# Altium schematic sheet Audio.SchDoc
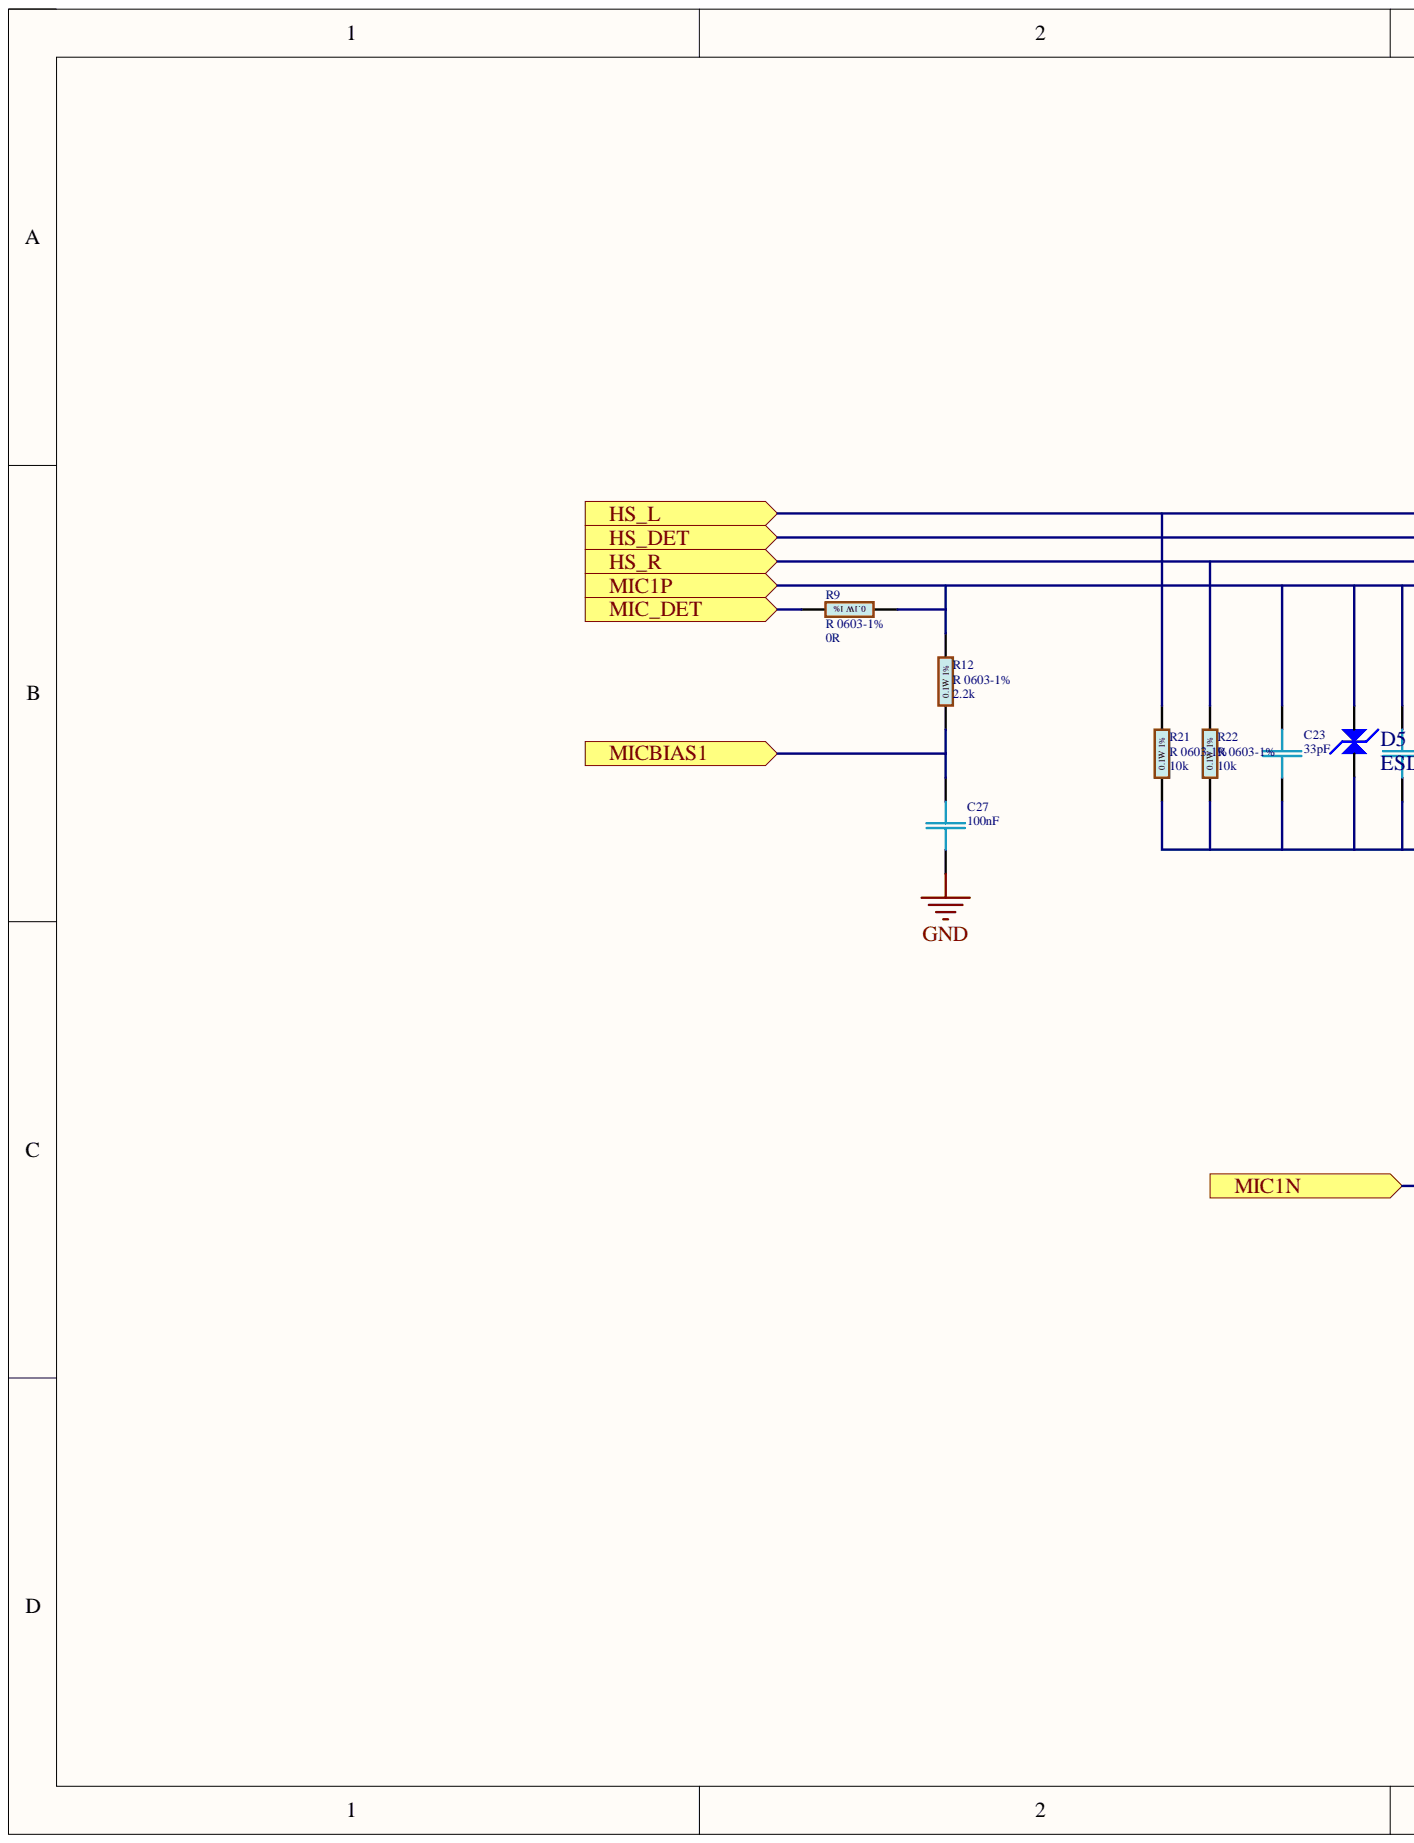
[diagram p0: Audio.SchDoc, left]
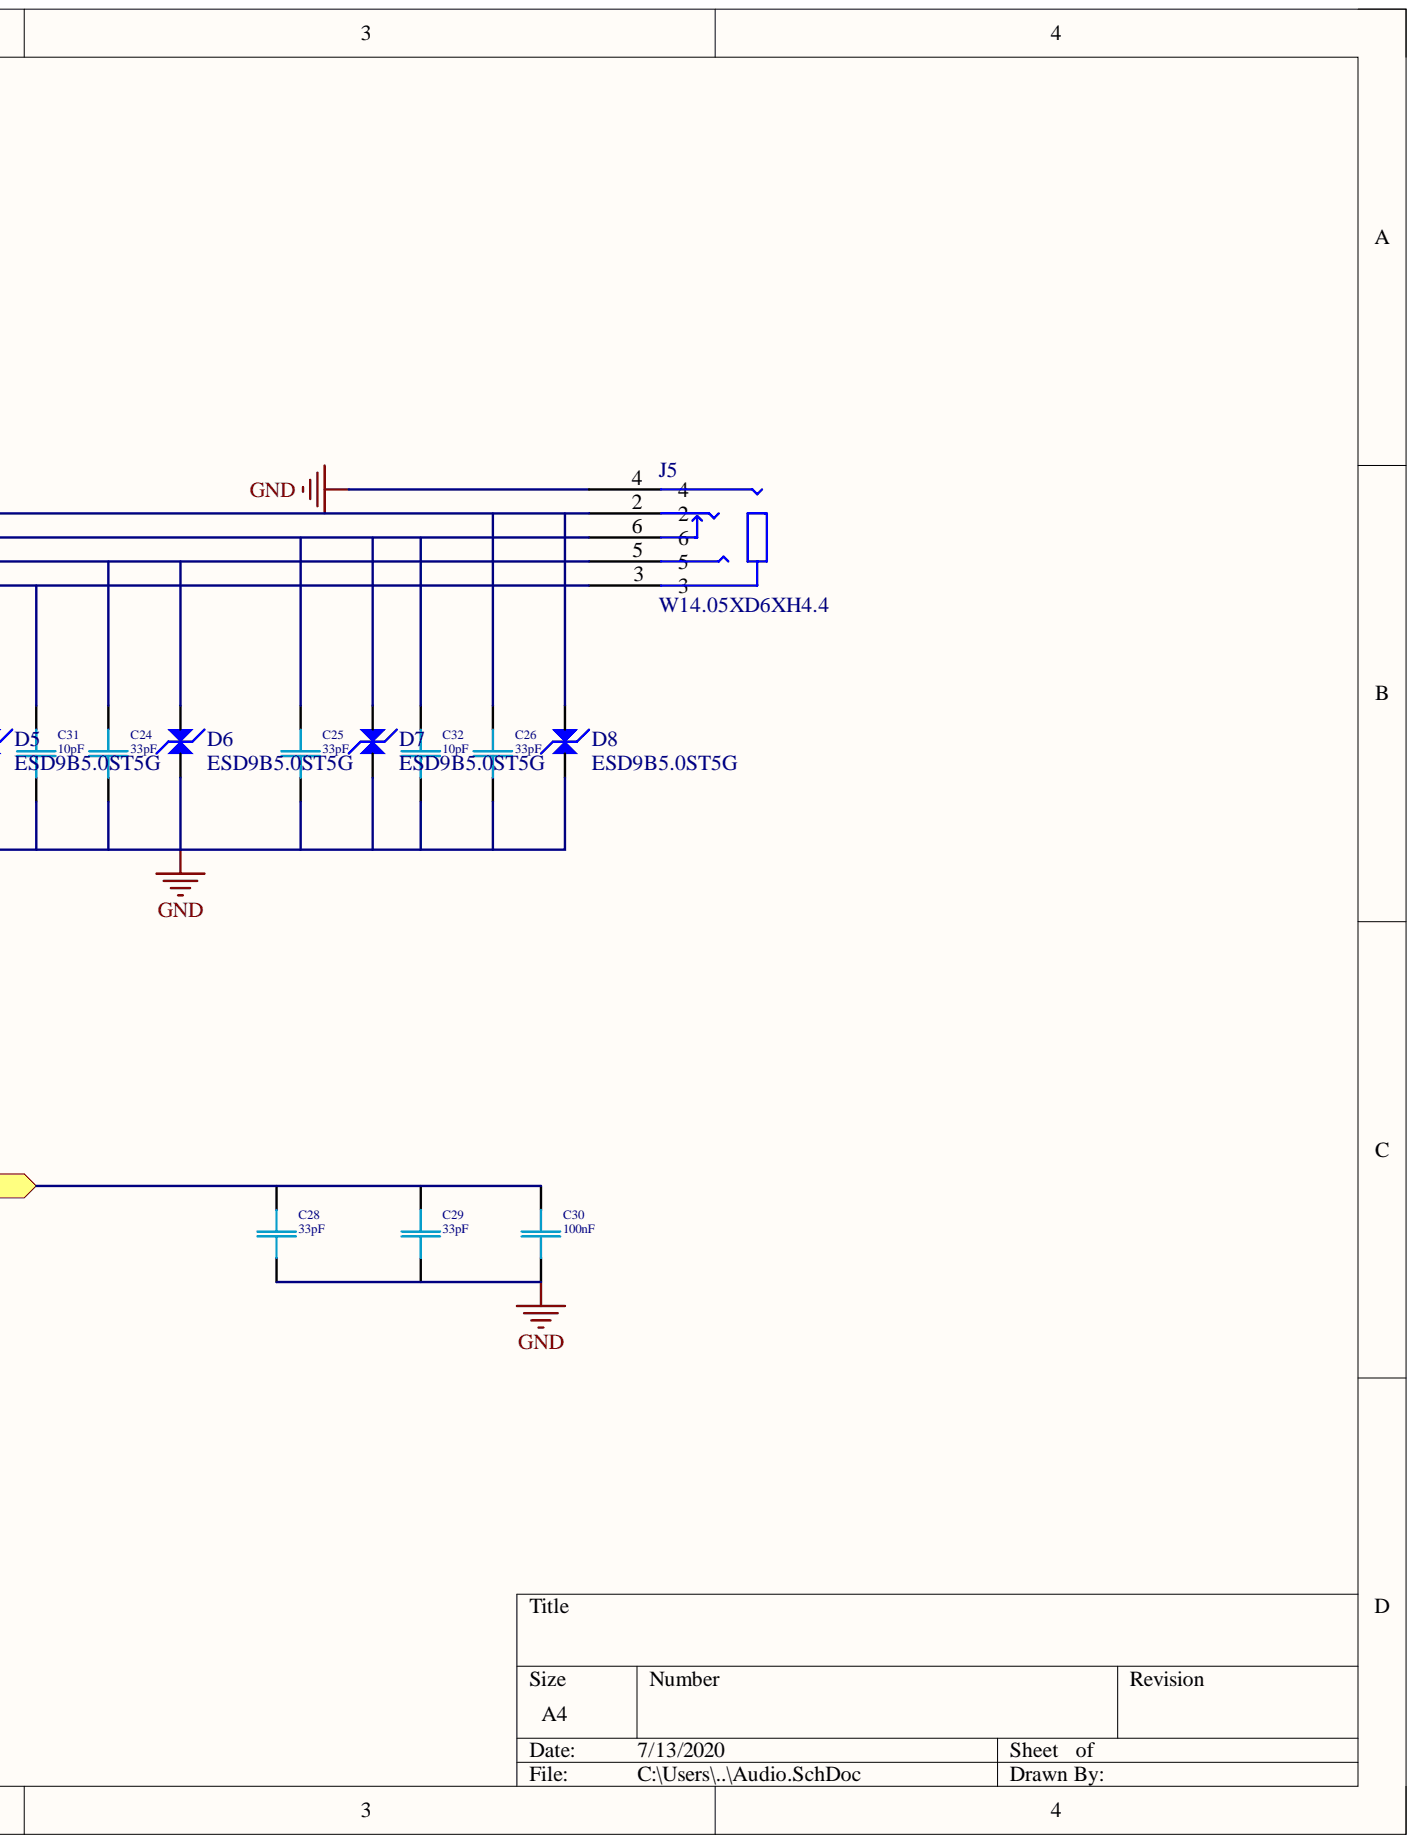
[diagram p1: Audio.SchDoc, right]

## Parts
C23  value 33pF  [C 0603(1608)x0.8]  (hidden comment: C 0603    50V X7R - 0*)
C24  value 33pF  [C 0603(1608)x0.8]  (hidden comment: C 0603    50V X7R - 0*)
C25  value 33pF  [C 0603(1608)x0.8]  (hidden comment: C 0603    50V X7R - 0*)
C26  value 33pF  [C 0603(1608)x0.8]  (hidden comment: C 0603    50V X7R - 0*)
C27  value 100nF  [C 0603(1608)x0.8]  (hidden comment: C 0603    50V X7R - 0*)
C28  value 33pF  [C 0603(1608)x0.8]  (hidden comment: C 0603    50V X7R - 0*)
C29  value 33pF  [C 0603(1608)x0.8]  (hidden comment: C 0603    50V X7R - 0*)
C30  value 100nF  [C 0603(1608)x0.8]  (hidden comment: C 0603    50V X7R - 0*)
C31  value 10pF  [C 0603(1608)x0.8]  (hidden comment: C 0603    50V X7R - 0*)
C32  value 10pF  [C 0603(1608)x0.8]  (hidden comment: C 0603    50V X7R - 0*)
D5  ESD9B5.0ST5G  [ESD9B5.0ST5G-Footprint-1]
D6  ESD9B5.0ST5G  [ESD9B5.0ST5G-Footprint-1]
D7  ESD9B5.0ST5G  [ESD9B5.0ST5G-Footprint-1]
D8  ESD9B5.0ST5G  [ESD9B5.0ST5G-Footprint-1]
J5  W14.05XD6XH4.4  [W14.05XD6XH4.4-Footprint-1]
R9  R 0603-1%  value 0R  Tolerance: 1%  [R 0603(1608) -1%]
R12  R 0603-1%  value 2.2k  Tolerance: 1%  [R 0603(1608) -1%]
R21  R 0603-1%  value 10k  Tolerance: 1%  [R 0603(1608) -1%]
R22  R 0603-1%  value 10k  Tolerance: 1%  [R 0603(1608) -1%]

## Ports
HS_DET, HS_L, HS_R, MIC1N, MIC1P, MIC_DET, MICBIAS1

## Nets
GND: C23.1 C24.1 C25.1 C26.1 C27.1 C28.1 C29.1 C30.1 C31.1 C32.1 D5.2 D6.2 D7.2 D8.2 J5.4 R21.1 R22.1
HS_DET: C25.2 C32.2 D7.1 J5.6 ; port HS_DET
HS_L: C26.2 D8.1 J5.2 R21.2 ; port HS_L
HS_R: C24.2 D6.1 J5.5 R22.2 ; port HS_R
MIC1N: C28.2 C29.2 C30.2 ; port MIC1N
MIC1P: C23.2 C31.2 D5.1 J5.3 R9.1 R12.2 ; port MIC1P
MIC_DET: R9.2 ; port MIC_DET
MICBIAS1: C27.2 R12.1 ; port MICBIAS1

## Library data of the parts
C23-C32 = CMP-023-0039-1: SMD 0603     50V X7R 10%; Manufacturer: Samsung; Package-mm: 1608; Case/Package: 0603; Component Kind: Standard; ComponentLink1Description: Datasheet Link; ComponentLink1URL: https://store.comet.bg/download-file.php?id=11; Design Item ID: C 0603    50V X7R - 0*; Library Name: <Company> Vault; Mount: SMT; Mount Type: SMT; Published: 07.04.2019; RoHS: Y; Signal Integrity: Capacitor; Simulation: Capacitor; Source: SMD Passive.SchLib; Technology: Ceramic  MLCC; TempCo: X7R; Temperature Range: -55°C ~ +125°C; Tolerance: 10%; VDC: 50V; Publisher: Hefest
D5-D8 = CMP-00148-1: Component Kind: Standard; Description: ESD9B5.0ST5G; Library Name: <Company> Vault
J5 = CMP-00158-1: 3.5mm耳机插座; Component Kind: Standard; Library Name: <Company> Vault
R9 R12 R21 R22 = CMP-025-0004-1: SMD 0603 0.1W     1%   75V; Manufacturer: YAGEO; Package-mm: 1608; Power: 100mW; TempCo: ±100ppm/°C; Value Code: 1001; Case/Package: 0603; Component Kind: Standard; ComponentLink1Description: Datasheet Link; ComponentLink1URL: https://store.comet.bg/download-file.php?id=1224; Design Item ID: R 0603-1%; Library Name: <Company> Vault; Mount: SMT; Mount Type: SMT; Price: 0.017; Published: 07.04.2019; RoHS: Y; Signal Integrity: Resistor; Simulation: Resistor; Source: SMD Passive.SchLib; Technology: Thick film; Temperature Range: -55°C ~ +155°C; VDC: 75V; Publisher: Hefest
